# Altium schematic sheet aethermobi.SchDoc
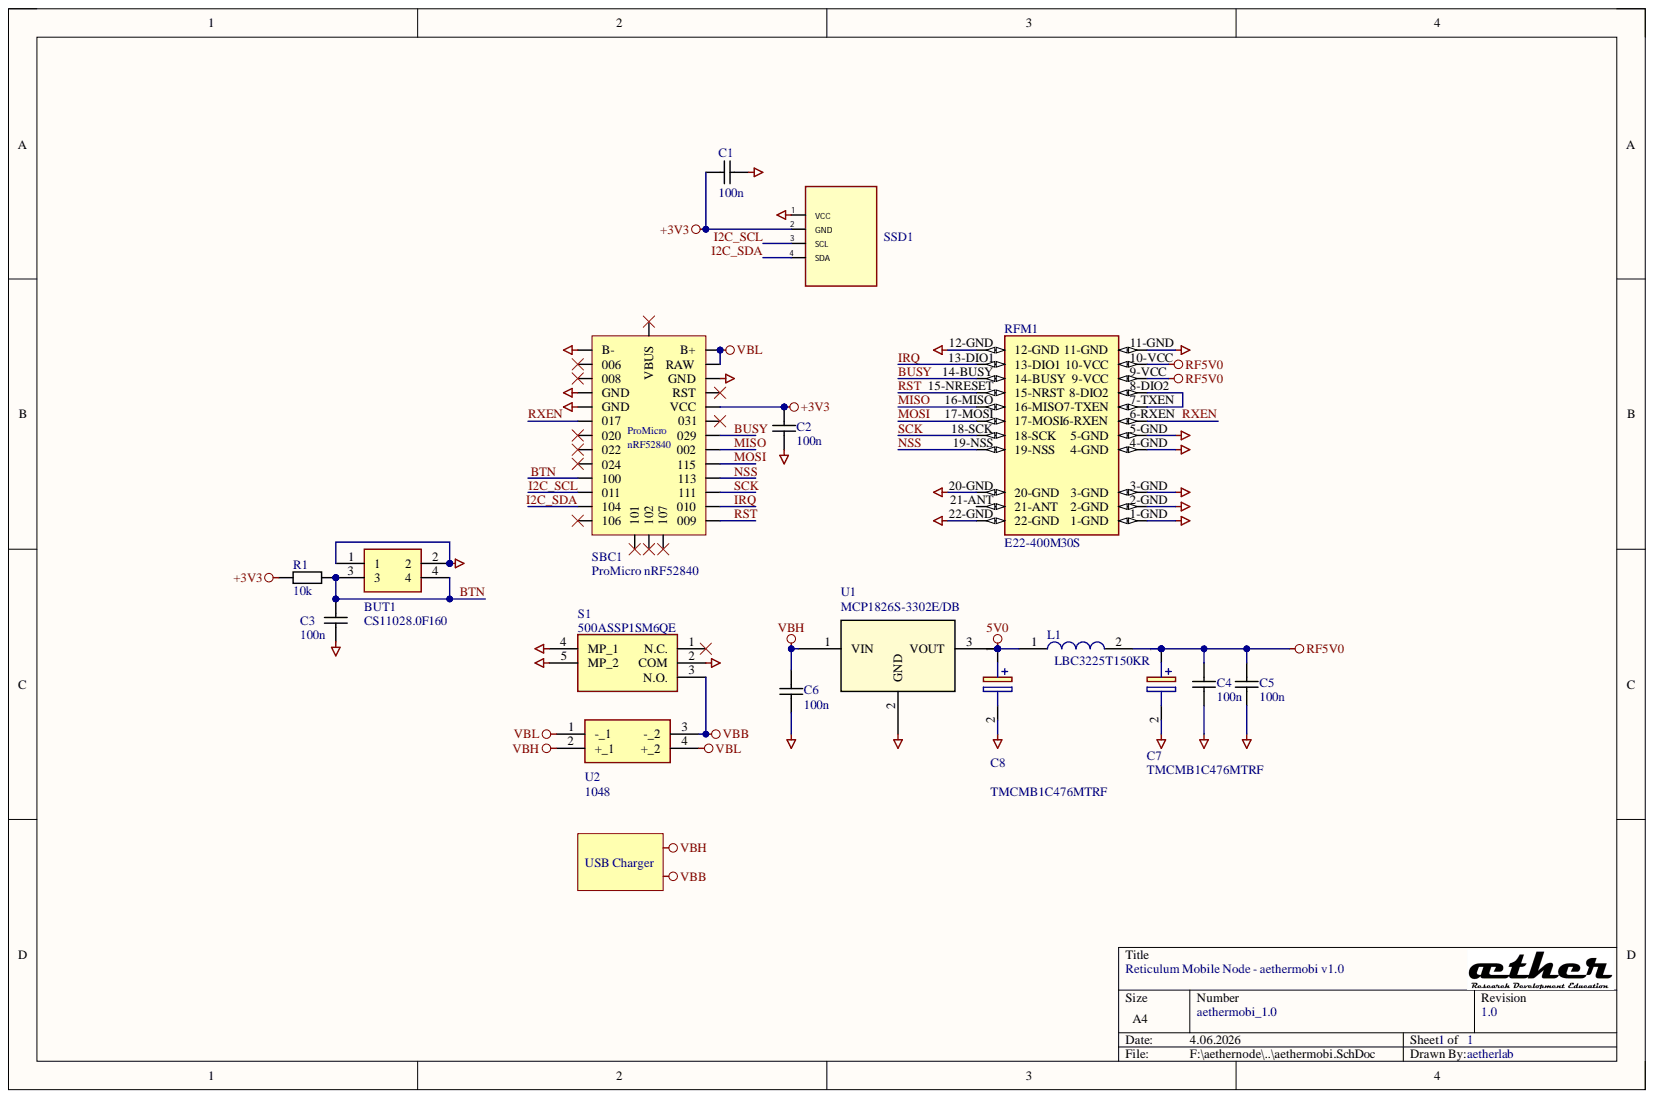
[diagram p0: aethermobi.SchDoc]

## Parts
BUT1  CS11028.0F160  [CS110280F160]
C1  100n  [C1206]
C2  100n  [C1206]
C3  100n  [C1206]
C4  100n  [C1206]
C5  100n  [C1206]
C6  100n  [C1206]
C7  TMCMB1C476MTRF  [TMCMB1C476MTRF]
C8  TMCMB1C476MTRF  [TMCMB1C476MTRF]
L1  LBC3225T150KR  [INDC3225X270N]
R1  10k  [R1206]
RFM1  E22-400M30S  [E22-400M30S]
S1  500ASSP1SM6QE  [500ASSP1SM6QE]
SBC1  ProMicro nRF52840  [PCBComponent_1]
SSD1  ~  [Nickie's Footprints:SSD1306]  (value not drawn)
U1  MCP1826S-3302E/DB  [SOT-223_3MC_MCH]
U2  1048  [1048]

## Nets
5V0: C8.1 L1.1 U1.3
+3V3: C1.2 C2.1 R1.1 SBC1.VCC SSD1.2(GND)
GND: BUT1.1 BUT1.2 C1.1 C2.2 C3.1 C4.1 C5.1 C6.1 C7.2 C8.2 RFM1.1-GND RFM1.2-GND RFM1.3-GND RFM1.4-GND RFM1.5-GND RFM1.11-GND RFM1.12-GND RFM1.20-GND RFM1.22-GND S1.2(COM) S1.4(MP_1) S1.5(MP_2) SBC1.B- SBC1.GND SSD1.1(VCC) U1.2
RF5V0: C4.2 C5.2 C7.1 L1.2 RFM1.9-VCC RFM1.10-VCC
VBB: S1.3(N.O.) U2.3(-_2)
VBH: C6.2 U1.1 U2.2(+_1)
VBL: SBC1.B+ SBC1.RAW U2.1(-_1) U2.4(+_2)
BTN: BUT1.3 BUT1.4 C3.2 R1.2 SBC1.100
BUSY: RFM1.14-BUSY SBC1.029
I2C_SCL: SBC1.011 SSD1.3(SCL)
I2C_SDA: SBC1.104 SSD1.4(SDA)
IRQ: RFM1.13-DIO1 SBC1.010
MISO: RFM1.16-MISO SBC1.002
MOSI: RFM1.17-MOSI SBC1.115
NSS: RFM1.19-NSS SBC1.113
RST: RFM1.15-NRESET(15-NRST) SBC1.009
RXEN: RFM1.6-RXEN SBC1.017
SCK: RFM1.18-SCK SBC1.111
NetRFM1_7-TXEN: RFM1.7-TXEN RFM1.8-DIO2

Not connected: RFM1 21-ANT; S1 1(N.C.); SBC1 006 008 020 022 024 031 101 102 106 107 RST VBUS

## Library data of the parts
BUT1 = CS11028.0F160: Switch; Manufacturer_Name: CIT Relay & Switch; Component Kind: Standard; Datasheet Link: https://www.citrelay.com/Catalog%20Pages/SwitchCatalog/CS1102.pdf; Height: 8mm; Manufacturer_Part_Number: CS11028.0F160
C1-C6 = Cap: Capacitor; Component Kind: Standard; Library Name: Cap.Schlib
C7 C8 = TMCMB1C476MTRF: Capacitor Polarised; Manufacturer_Name: Vishay; Arrow Part Number: TMCMB1C476MTRF; Arrow Price/Stock: https://www.arrow.com/en/products/tmcmb1c476mtrf/vishay?utm_currency=USD&region=nac; Component Kind: Standard; Datasheet Link: https://www.vishay.com/docs/40178/tmcm.pdf; Height: 2.1mm; Manufacturer_Part_Number: TMCMB1C476MTRF; Mouser Part Number: 74-TMCMB1C476MTRF; Mouser Price/Stock: https://www.mouser.co.uk/ProductDetail/Vishay-Sprague/TMCMB1C476MTRF?qs=NgbZBzc1CyEmJWrRgGfNNQ%3D%3D
  C8: Library Name: aethernode.SCHLIB
L1 = LBC3225T150KR: Inductor; Manufacturer_Name: TAIYO YUDEN; Component Kind: Standard; Datasheet Link: https://www.mouser.hk/datasheet/2/396/wound01_e-1509533.pdf; Height: 2.7mm; Manufacturer_Part_Number: LBC3225T150KR; Mouser Part Number: 963-LBC3225T150KR; Mouser Price/Stock: https://www.mouser.co.uk/ProductDetail/Taiyo-Yuden/LBC3225T150KR?qs=PzICbMaShUdTXd%2FGRKjRBA%3D%3D
R1 = Resistor: Component Kind: Standard; Description: Resistor; Library Name: Res.Schlib
RFM1 = E22-400M30S: SX1268 433/470MHz SPI SMD LoRa Module; MF: EBYTE; Check_prices: https://www.snapeda.com/parts/E22-400M30S/EBYTE/view-part/?ref=eda; Component Kind: Standard; Library Name: E22-400M30S.IntLib; MP: E22-400M30S; Package: Package; SnapEDA_Link: https://www.snapeda.com/parts/E22-400M30S/EBYTE/view-part/?ref=snap
S1 = 500ASSP1SM6QE: Switch; Manufacturer_Name: E-Switch; Component Kind: Standard; Datasheet Link: https://sten-eswitch-13110800-production.s3.amazonaws.com/system/asset/product_line/data_sheet/116/500A.pdf; Height: 6.08mm; Manufacturer_Part_Number: 500ASSP1SM6QE; Mouser Part Number: 612-500ASSP1SM6QE; Mouser Price/Stock: https://www.mouser.co.uk/ProductDetail/E-Switch/500ASSP1SM6QE?qs=f57gQzlyLirSuKtk15%2FoHg%3D%3D
SSD1 = SSD1306: Component Kind: Standard; Library Name: Nickie's Lib; Value: ~
U1 = MCP1826S-3302EDB: No Description Available; Manufacturer_Name: Microchip; Component Kind: Standard; Library Name: MCP1826S-3302EDB.SchLib; Manufacturer_Part_Number: MCP1826S-3302E/DB; RefDes: RefDes; Type: Type; Copyright: Copyright (C) 2026 Ultra Librarian. All rights reserved.
U2 = 1048: Undefined or Miscellaneous; Manufacturer_Name: Keystone Electronics; Component Kind: Standard; Datasheet Link: http://www.keyelco.com/product-pdf.cfm?p=920; Manufacturer_Part_Number: 1048; Mouser Part Number: 534-1048; Mouser Price/Stock: https://www.mouser.co.uk/ProductDetail/Keystone-Electronics/1048?qs=%2F7TOpeL5Mz5jXkg8vI8Dyw%3D%3D
SBC1 = ProMicro nRF52840: Component Kind: Standard; Library Name: ProMicro_nRF52840.SchLib
